# Altium schematic sheet [19]-CAMERA_PWR_CONNECTORS.SchDoc([19]-CAMERA_PWR_CONNECTORS)
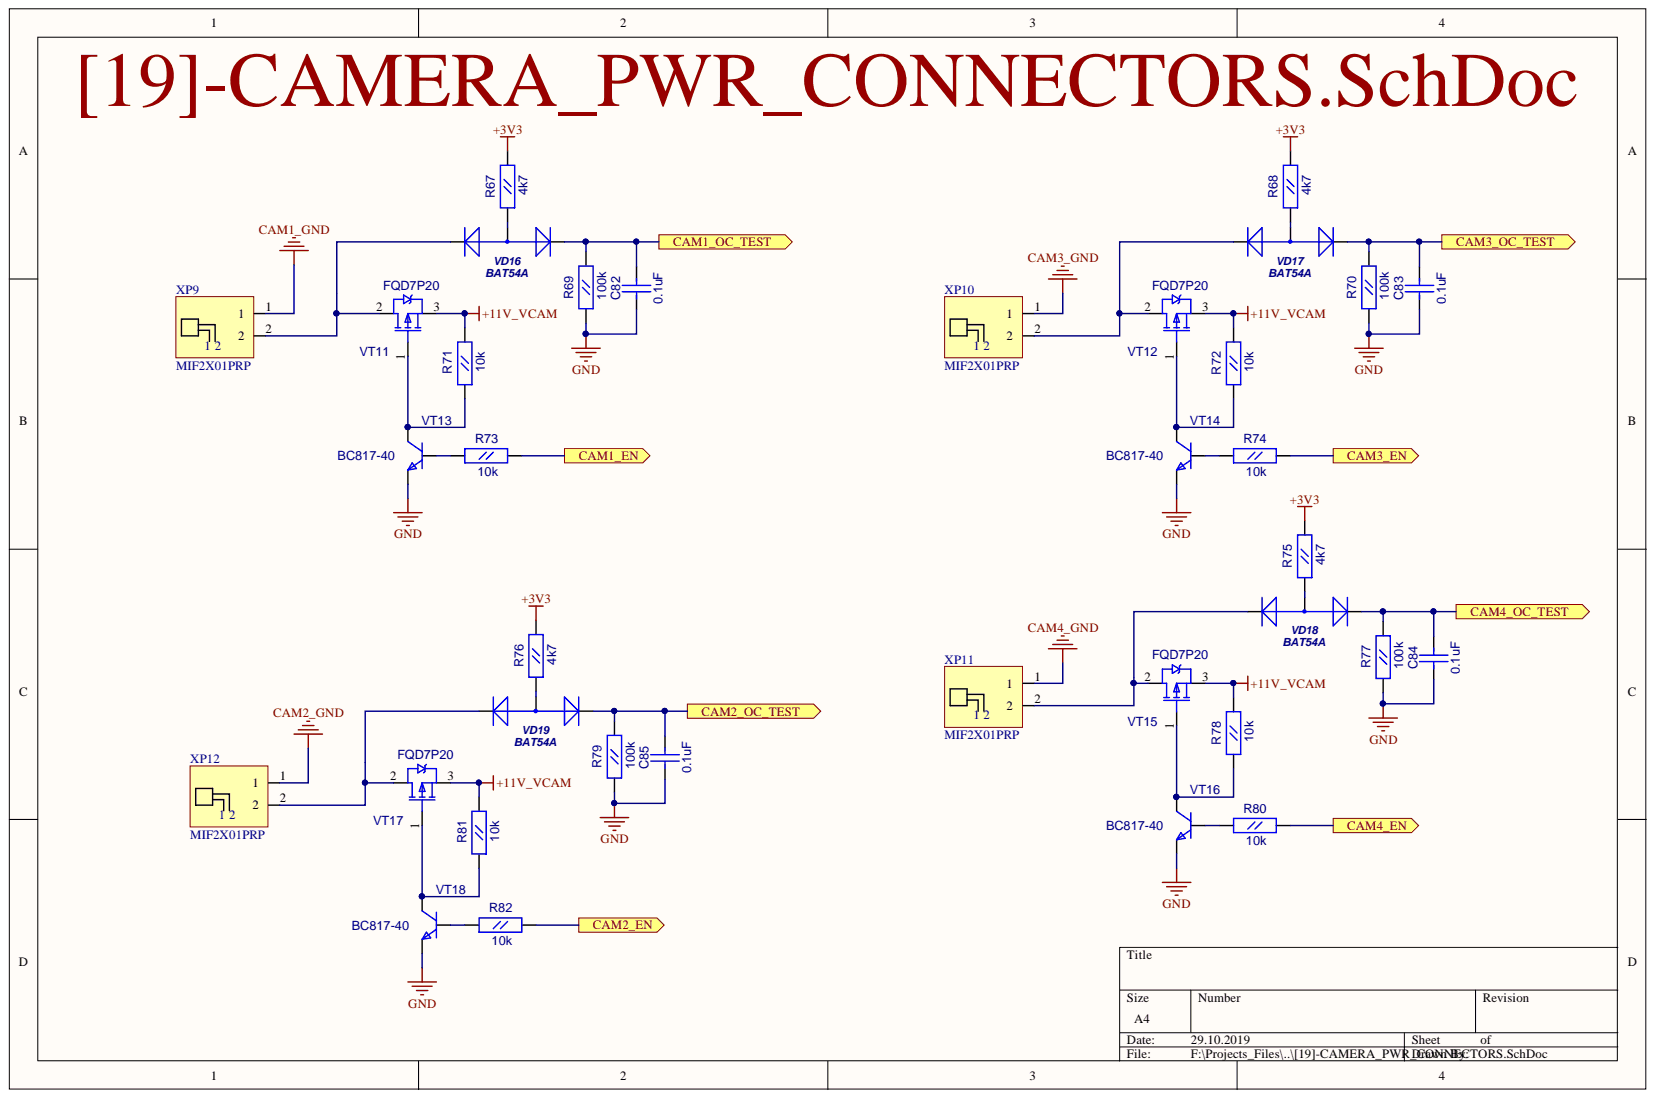
[diagram p0: [19]-CAMERA_PWR_CONNECTORS.SchDoc]

## Parts
C82  0.1uF  [CC0603]
C83  0.1uF  [CC0603]
C84  0.1uF  [CC0603]
C85  0.1uF  [CC0603]
R67  4k7  [RC0603]
R68  4k7  [RC0603]
R69  100k  [RC0603]
R70  100k  [RC0603]
R71  10k  [RC0603]
R72  10k  [RC0603]
R73  10k  [RC0603]
R74  10k  [RC0603]
R75  4k7  [RC0603]
R76  4k7  [RC0603]
R77  100k  [RC0603]
R78  10k  [RC0603]
R79  100k  [RC0603]
R80  10k  [RC0603]
R81  10k  [RC0603]
R82  10k  [RC0603]
VD16  BAT54A  [SOT-23(123)]
VD17  BAT54A  [SOT-23(123)]
VD18  BAT54A  [SOT-23(123)]
VD19  BAT54A  [SOT-23(123)]
VT11  FQD7P20  [D-PAK(TO-252AA)]
VT12  FQD7P20  [D-PAK(TO-252AA)]
VT13  BC817-40  [SOT-23(123)]
VT14  BC817-40  [SOT-23(123)]
VT15  FQD7P20  [D-PAK(TO-252AA)]
VT16  BC817-40  [SOT-23(123)]
VT17  FQD7P20  [D-PAK(TO-252AA)]
VT18  BC817-40  [SOT-23(123)]
XP9  MIF2X01PRP  [MIF2x01PRP]
XP10  MIF2X01PRP  [MIF2x01PRP]
XP11  MIF2X01PRP  [MIF2x01PRP]
XP12  MIF2X01PRP  [MIF2x01PRP]

## Ports
CAM1_EN, CAM1_OC_TEST, CAM2_EN, CAM2_OC_TEST, CAM3_EN, CAM3_OC_TEST, CAM4_EN, CAM4_OC_TEST

## Nets
+3V3: R67.2 R68.2 R75.2 R76.2
+11V_VCAM: R71.2 R72.2 R78.2 R81.2 VT11.3 VT12.3 VT15.3 VT17.3
CAM1_GND: XP9.1
CAM2_GND: XP12.1
CAM3_GND: XP10.1
CAM4_GND: XP11.1
GND: C82.1 C83.1 C84.1 C85.1 R69.1 R70.1 R77.1 R79.1 VT13.2 VT14.2 VT16.2 VT18.2
CAM1_EN: R73.2 ; port CAM1_EN
CAM1_OC_TEST: C82.2 R69.2 VD16.2 ; port CAM1_OC_TEST
CAM2_EN: R82.2 ; port CAM2_EN
CAM2_OC_TEST: C85.2 R79.2 VD19.2 ; port CAM2_OC_TEST
CAM3_EN: R74.2 ; port CAM3_EN
CAM3_OC_TEST: C83.2 R70.2 VD17.2 ; port CAM3_OC_TEST
CAM4_EN: R80.2 ; port CAM4_EN
CAM4_OC_TEST: C84.2 R77.2 VD18.2 ; port CAM4_OC_TEST
NetR67_1: R67.1 VD16.3
NetR68_1: R68.1 VD17.3
NetR71_1: R71.1 VT11.1 VT13.3
NetR72_1: R72.1 VT12.1 VT14.3
NetR73_1: R73.1 VT13.1
NetR74_1: R74.1 VT14.1
NetR75_1: R75.1 VD18.3
NetR76_1: R76.1 VD19.3
NetR78_1: R78.1 VT15.1 VT16.3
NetR80_1: R80.1 VT16.1
NetR81_1: R81.1 VT17.1 VT18.3
NetR82_1: R82.1 VT18.1
NetVD16_1: VD16.1 VT11.2 XP9.2
NetVD17_1: VD17.1 VT12.2 XP10.2
NetVD18_1: VD18.1 VT15.2 XP11.2
NetVD19_1: VD19.1 VT17.2 XP12.2

## Library data of the parts
C82-C85 = CC0603: Value: 0.1uF; Description: CC0603; Component Kind: Standard; Library Name: fizik_lib.SchLib
R67-R82 = RC0603: Description: RC0603; Component Kind: Standard; Library Name: fizik_lib.SchLib
  R67 R68 R75 R76: Value: 4k7
  R69 R70 R77 R79: Value: 100k
  R71-R74 R78 R80-R82: Value: 10k
VD16-VD19 = BAT54A: 1.0 Ampere General Purpose Rectifier; ComponentField6: Published 8-Jun-2000, Modified 11-July-2000: SIM Model Added.; Simulation: DIODE; Component Kind: Standard; Library Name: fizik_lib.SchLib
VT11 VT12 VT15 VT17 = IRFR9220: HEXFET Power MOSFET; OriginalName: IRLML5103; ComponentField7: Published 8-Jun-2000; Value: FQD7P20; Component Kind: Standard; Library Name: gprs_modem_2.SchLib
VT13 VT14 VT16 VT18 = BC846BLT1: NPN 500ma transistor; ComponentField7: Published 29-Sep-2000; Value: BC817-40; Simulation: BC846BLT1; OriginalName: BC846BLT1; Component Kind: Standard; Library Name: fizik_lib.SchLib
XP9-XP12 = MIF2X01PRP: Molex connector 3.0mm pitch; Value: MIF2X01PRP; Component Kind: Standard; Library Name: fizik_lib.SchLib
